# Altium schematic sheet USB.SchDoc
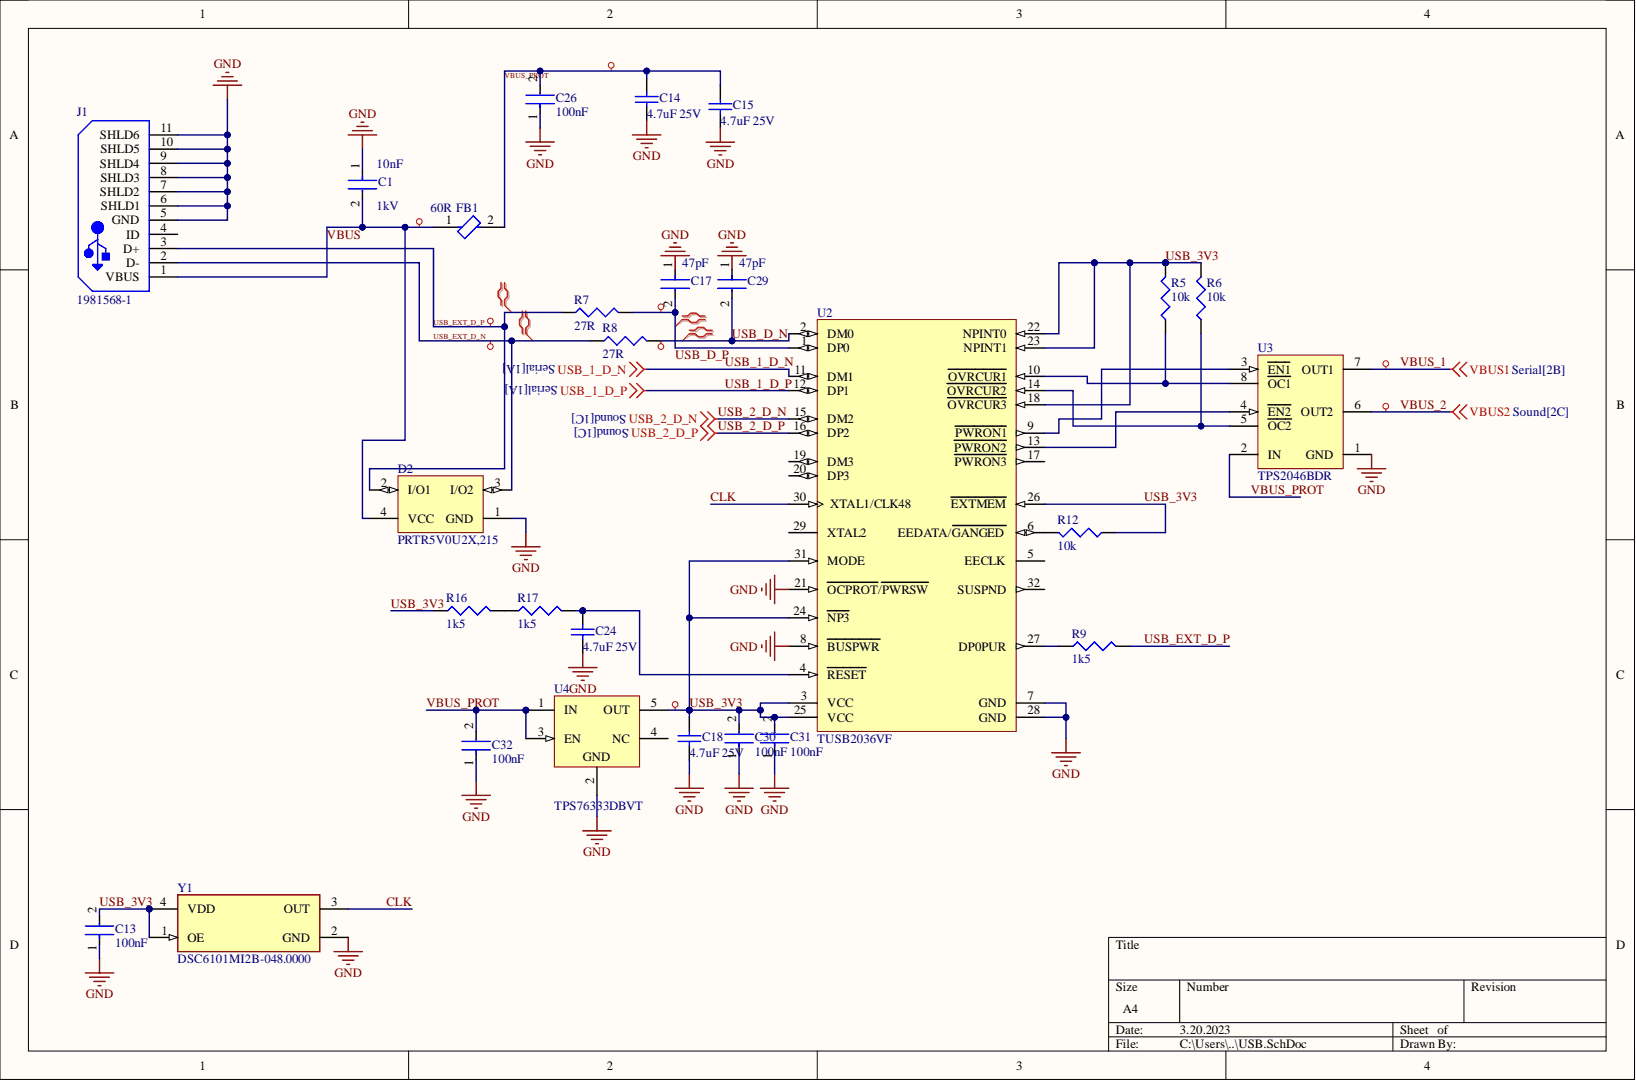
[diagram p0: USB.SchDoc]

## Parts
C1  Capacitance: 10nF  Voltage Rating: 1kV  [FP-C4532-200-0_4-IPC_B]  (hidden comment: C4532X7R3A103K200KA)
C13  Capacitance: 100nF  [FP-CL10-IPC_B]  (hidden comment: CL10B104KC8NNNC)
C14  4.7uF 25V  value 4.7uF  [CAPC1210(3225)220_M]
C15  4.7uF 25V  value 4.7uF  [CAPC1210(3225)220_M]
C17  Capacitance: 47pF  [FP-CL10-IPC_C]  (hidden comment: CL10C470JC8NNNC)
C18  4.7uF 25V  value 4.7uF  [CAPC1210(3225)220_M]
C24  4.7uF 25V  value 4.7uF  [CAPC1210(3225)220_M]
C26  Capacitance: 100nF  [FP-CL10-IPC_B]  (hidden comment: CL10B104KC8NNNC)
C29  Capacitance: 47pF  [FP-CL10-IPC_C]  (hidden comment: CL10C470JC8NNNC)
C30  Capacitance: 100nF  [FP-CL10-IPC_B]  (hidden comment: CL10B104KC8NNNC)
C31  Capacitance: 100nF  [FP-CL10-IPC_B]  (hidden comment: CL10B104KC8NNNC)
C32  Capacitance: 100nF  [FP-CL10-IPC_B]  (hidden comment: CL10B104KC8NNNC)
D2  PRTR5V0U2X,215  [NXP-SOT143B-4_V]
FB1  Impedance: 60R  [FP-BLM41-0_2-IPC_B]  (hidden comment: BLM41PG600SN1L)
J1  1981568-1  [TECO-1981568-5_V]
R5  10k  [J1-0603]
R6  10k  [J1-0603]
R7  27R  [J1-0603]
R8  27R  [J1-0603]
R9  1k5  [J1-0603]
R12  10k  [J1-0603]
R16  1k5  [J1-0603]
R17  1k5  [J1-0603]
U2  TUSB2036VF  [VF0032A_N]
U3  TPS2046BDR  [D0008A_L]
U4  TPS76333DBVT  [DBV0005A_N]
Y1  DSC6101MI2B-048.0000  [FP-C04-C04-1200-0]

## Nets
GND: C1.1 C13.1 C14.1 C15.1 C17.1 C18.1 C24.1 C26.1 C29.1 C30.1 C31.1 C32.1 D2.1(GND) J1.5(GND) J1.6(SHLD1) J1.7(SHLD2) J1.8(SHLD3) J1.9(SHLD4) J1.10(SHLD5) J1.11(SHLD6) U2.7(GND) U2.8(BUSPWR) U2.21(OCPROT/PWRSW) U2.28(GND) U3.1(GND) U4.2 Y1.2(GND)
CLK: U2.30(XTAL1/CLK48) Y1.3(OUT)
USB_1_D_N: U2.11(DM1)
USB_1_D_P: U2.12(DP1)
USB_2_D_N: U2.15(DM2)
USB_2_D_P: U2.16(DP2)
USB_3V3: C13.2 C18.2 C30.2 C31.2 R5.2 R6.2 R12.1 R16.1 U2.3(VCC) U2.18(OVRCUR3) U2.22(NPINT0) U2.23(NPINT1) U2.24(NP3) U2.25(VCC) U2.26(EXTMEM) U2.31(MODE) U4.5(OUT) Y1.1(OE) Y1.4(VDD)
USB_D_N: C29.2 R8.2 U2.2(DM0)
USB_D_P: C17.2 R7.2 U2.1(DP0)
USB_EXT_D_N: D2.3(I/O2) J1.2(D-) R8.1
USB_EXT_D_P: D2.2(I/O1) J1.3(D+) R7.1 R9.2
VBUS: C1.2 D2.4(VCC) FB1.1 J1.1(VBUS)
VBUS_1: U3.7(OUT1)
VBUS_2: U3.6(OUT2)
VBUS_PROT: C14.2 C15.2 C26.2 C32.2 FB1.2 U3.2(IN) U4.1(IN) U4.3(EN)
NetC24_2: C24.2 R17.2 U2.4(RESET)
NetR5_1: R5.1 U2.10(OVRCUR1) U3.8(OC1)
NetR6_1: R6.1 U2.14(OVRCUR2) U3.5(OC2)
NetR9_1: R9.1 U2.27(DP0PUR)
NetR12_2: R12.2 U2.6(EEDATA/GANGED)
NetR16_2: R16.2 R17.1
NetU2_9: U2.9(PWRON1) U3.3(EN1)
NetU2_13: U2.13(PWRON2) U3.4(EN2)

Not connected: J1 4(ID); U2 5(EECLK) 17(PWRON3) 19(DM3) 20(DP3) 29(XTAL2) 32(SUSPND); U4 4(NC)

## Library data of the parts
C1 = CMP-08246-006993-1: Multilayer Ceramic Capacitors 10nF ±10% 1000V X7R SMD 1812; Case Code (Imperial): 1812; Case Code (Metric): 4532; Case/Package: 1812; Component Kind: Standard; Depth: 3.2mm; Dielectric: X7R; JLCPCBPartNum: C577346; Lead Free: Lead Free; Length: 4.5mm; Library Name: Altium Content Vault; Max Operating Temperature: 125°C; Min Operating Temperature: -55°C; Mount: Surface Mount; Number of Pins: 2; Package Quantity: 1; Packaging: Tape and Reel; REACH SVHC: No SVHC; Resistor Type: Ceramic; RoHS Compliant: Yes; Series: C; Termination: SMD/SMT; Thickness: 0.087inch; Tolerance: 10%; Voltage Rating (DC): 1kV; Weight: 0.000952oz; Width: 0.126inch
C13 C26 C30-C32 = CMP-13271-004036-1: Cap Ceramic 100nF 100V X7R ±10% Pad SMD 0603 +125°C T/R; Case Code (Imperial): 0603; Case Code (Metric): 1608; Case/Package: 0603; Component Kind: Standard; JLCPCBPartNum: C15725; Length: 0.063inch; Library Name: Altium Content Vault; Max Operating Temperature: 125°C; Min Operating Temperature: -55°C; Mount: Surface Mount; Packaging: Cut Tape; Thickness: 0.035inch; Tolerance: 10%; Voltage Rating: 100V; Width: 0.031inch
C14 C15 C18 C24 = CMP-1038-02151-2: CAP 4.7uF 25V ±10% 1210 (3225 Metric) Thickness 2.2mm SMD; Case-EIA: 1210; Case-Metric: 3225; Tolerance: Â±10%; Component Kind: Standard; JLCPCBPartNum: C86052; Library Name: Altium Content Vault; Max Thickness: 2.2 mm; Rated Voltage: 25 V; Technology: SMT
C17 C29 = CMP-13271-001416-1: Cap Ceramic 47pF 100V C0G ±5% Pad SMD 0603 +125°C T/R; Case Code (Imperial): 0603; Case Code (Metric): 1608; Case/Package: 0603; Component Kind: Standard; Depth: 0.8mm; Dielectric: C0G; Height: 0.8mm; JLCPCBPartNum: C1671; Length: 1.6mm; Library Name: Altium Content Vault; Material: Ceramic; Max Operating Temperature: 125°C; Min Operating Temperature: -55°C; Mount: Surface Mount; Packaging: Tape and Reel; Radiation Hardening: No; Thickness: 0.035inch; Tolerance: 5%; Voltage Rating: 100V; Voltage Rating (DC): 100V; Width: 0.031inch
D2 = CMP-2000-05285-1: Ultra Low Capacitance Double Rail-to-Rail ESD Protection Diode, 5.5 V, 1 pF, -40 to 85 degC, 4-Pin SOT143B, RoHS, Tape and Reel; Manufacturer: NXP Semiconductors; Capacitance Value: 16pF; Configuration: Quad; Direction Type: Uni-Directional; ESD Protection Voltage: 8KV@ContactDisc; Leakage Current: 0.1uA; Number of Elements: 1; Product Depth (mm): 1.4mm; Product Height (mm): 1mm; Product Length (mm): 3mm; Rad Hardened: No; Reverse Breakdown Voltage: 6V; Reverse Stand-off Voltage: 5.5V; Suppressor Type: DiodeArrays; Component Kind: Standard; Datasheet URL: https://datasheet.ciiva.com/4479/prtr5v0u2x-102853-4479461.pdf; Datasheet Version: Rev. 02, 01/2008; JLCPCBPartNum: C12333; Leakage Current (Max): 0.1uA; Library Name: Altium Content Vault; Manufacturer URL: http://www.nxp.com/; Mounting: SurfaceMount; Mounting Style: SurfaceMount; Operating Temp Range: -40Cto85C; Operating Temperature Classification: Industrial; Operating Temperature Max Deg. C: 85C; Operating Temperature Min Deg. C: -40C; Package / Case: SOT; Package Type: SOT; Packaging: TapeandReel; Pin Count: 3+Tab; Polarity: Uni-Directional; Working Voltage: 5.5V; Working Voltage (Max): 5.5V
FB1 = CMP-0686-00754-3: Ferrite Bead, 1806, 60Ω @ 100MHz, 0.009Ω, 6A; Manufacturer Series: BLM41P; DC Resistance (DCR): 9mR; Number of Circuits: 1; Shielding: Unshielded; Tolerance: 25%; Case Code (Imperial): 1806; Case Code (Metric): 4516; Case/Package: 1806; Component Kind: Standard; Contact Plating: Tin; Core Material: Ferrite; Current: 6A; Current Rating: 6A; DC Current: 6A; DC Resistance-Max: 9mR; Depth: 1.6mm; Frequency: 100MHz; Height: 1.6mm; Inductor Type: FERRITE BEAD; JLCPCBPartNum: C85844; Lead Free: Lead Free; Length: 4.5mm; Library Name: Altium Content Vault; Material: Ferrite; Max Current Rating: 6A; Max DC Current: 6A; Max Operating Temperature: 125°C; Military Standard: Not; Min Operating Temperature: -55°C; Number of Functions: 1; Number of Lines: 1; Number of Pins: 2; Package Quantity: 1; Packaging: Tape and Reel; Radiation Hardening: No; REACH SVHC: No SVHC; RoHS Compliant: Yes; Series: BLM41P; Terminal Finish: Tin; Termination: SMD/SMT; Test Frequency: 100MHz; Width: 1.6mm
J1 = CMP-2000-05408-1: Micro USB Receptacle Standard Type B Assy, 5-Pin SMD, RoHS, Tape and Reel; Manufacturer: TE Connectivity; Body Orientation: RightAngle; Current Rating (Max): 1/ContactA; Gender: RCP; Number of Contacts: 5POS; Number of Ports: 1Port; Pitch (mm): 0.65mm; Product Depth (mm): 5.6mm; Product Height (mm): 3mm; Product Length (mm): 8mm; Voltage Rating Max: 30VAC; Component Kind: Standard; Contact Material: CopperAlloy; Contact Plating: GoldOverNickel; Datasheet URL: http://www.mouser.com/ds/2/418/NG_CD_1981568_E2-621296.pdf; Datasheet Version: Rev. E2, 08/2015; Housing Material: Thermoplastic; JLCPCBPartNum: C428487; Library Name: Altium Content Vault; Manufacturer URL: http://www.te.com/; Mounting Style: SurfaceMount; Number of Terminals: 5; Operating Temp Range: -55Cto85C; Operating Temperature Max Deg. C: 85C; Operating Temperature Min Deg. C: -55C; Packaging: TapeandReel; Termination Method: Solder; Type: MicroUSB
R5-R9 R12 R16 R17 = Res3: Resistor; Component Kind: Standard; LatestRevisionDate: 29-May-2009; LatestRevisionNote: IPC-7351 Footprint Added.; Library Name: Miscellaneous Devices.IntLib; PackageDescription: Chip Resistor; PackageReference: J1-0603; Published: 8-Jun-2000; Signal Integrity: Res; Simulation: RESISTOR; Publisher: Altium Limited
  R5 R6 R12: JLCPCBPartNum: C25804; Value: 10k
  R7 R8: JLCPCBPartNum: C192531; Value: 27R
  R9 R16 R17: JLCPCBPartNum: C192164; Value: 1k5
U2 = CMP-0309-00077-2: 2 / 3-Port Hub for USB with Optional Serial EEPROM Interface, 3.3V, 0 to 70 degC, 32-Pin LQFP (VF), Green (RoHS & no Sb/Br); Manufacturer: Texas Instruments; SubFamily: USB Hub Controller; Supply Voltage(s)(V): 3.3; USB Full Speed: True; USB Speed: Full; Component Kind: Standard; ComponentLink1Description: Datasheet; ComponentLink1URL: http://www.ti.com/general/docs/lit/getliterature.tsp?genericPartNumber=TUSB2036&fileType=pdf; ComponentLink2Description: Package Specification; ComponentLink2URL: http://www.ti.com/litv/pdf/mtqf002b; DatasheetVersion: SLLS372E; Eco-Plan: Green (RoHS & no Sb/Br); Integrated MCU Architecture: N/A; JLCPCBPartNum: C43665; Library Name: Altium Content Vault; Mounting Technology: Surface Mount; PackageDescription: 32-Pin Plastic Quad Flatpack, 7 x 7 mm Body, 0.8 mm Pitch; PackageReference: VF0032A; PackageVersion: revD, Apr-2000; PartNumber: TUSB2036VF
U3 = CMP-0337-00031-2: Current-Limited Power-Distribution Switch, 0.5 A Current Limit, -40 to 85 degC, 8-pin SOIC (D), Green (RoHS & no Sb/Br); Manufacturer: Texas Instruments; Continuous Current(Max)(A): 0.25; Current Limit(A): 0.5; Enable: Active Low; NEMKO: TRUE; Number of Switches: 2; Rating: Catalog; rDS(on) per FET(Typ)(mOhms): 70; Vin(Max)(V): 5.5; Vin(Min)(V): 2.7; Code_JEDEC: MS-012AA; Component Kind: Standard; ComponentLink1Description: Datasheet; ComponentLink1URL: http://www.ti.com/general/docs/lit/getliterature.tsp?genericPartNumber=TPS2046B&fileType=pdf; DatasheetVersion: SLVS532C; Eco-Plan: Green (RoHS & no Sb/Br); Library Name: Altium Content Vault; Mounting Technology: Surface Mount; PackageDescription: 8-Pin Plastic Small Outline Integrated Circuit, 4.9 x 3.9 mm, Pitch 1.27 mm Pitch; PackageReference: D0008A; PackageVersion: revM, Jun-2011; PartNumber: TPS2046BDR; UL Listed: TRUE
U4 = CMP-0394-00563-2: Single Output LDO, 150 mA, Fixed 3.3 V Output, 2.7 to 10 V Input, with Low IQ, 5-pin SOT-23 (DBV), -40 to 125 degC, Green (RoHS & no Sb/Br); Manufacturer: Texas Instruments; Accuracy(%): 3; Fixed Output Options(V): 3.3; Iout(Max)(A): 0.15; Iq(Typ)(mA): 0.08; SubFamily: Single Channel LDO; Vdo(Typ)(mV): 300; Vin(Max)(V): 10; Vin(Min)(V): 2.7; Code_JEDEC: MO-178; Component Kind: Standard; ComponentLink1Description: Manufacturer URL; ComponentLink1URL: http://www.ti.com/; ComponentLink2Description: Datasheet; ComponentLink2URL: http://www.ti.com/general/docs/lit/getliterature.tsp?genericPartNumber=TPS76333&fileType=pdf; ComponentLink3Description: Package Specification; ComponentLink3URL: http://www.ti.com/litv/pdf/mpds018i; DatasheetVersion: SLVS181H; Eco-Plan: Green (RoHS & no Sb/Br); JLCPCBPartNum: C7100; Library Name: Altium Content Vault; Mounting Technology: Surface Mount; PackageDescription: 5-Pin Plastic Small Outline, Body 1.60 Ãƒâ€” 2.90 mm, Pitch 0.95 mm; PackageReference: DBV0005A; PackageVersion: 4073253-4/K; PartNumber: TPS76333DBVT; Vout Adj(Max)(V): 3.3; Vout Adj(Min)(V): 3.3
Y1 = CMP-06255-000002-1: MEMS OSC XO 48.0000MHZ CMOS SMD; Manufacturer: Microchip; Manufacturer Part Number: DSC6101MI2A-024.0000T; Frequency: 24MHz; Max Junction Temp: +150°C; Max Supply Voltage: 3.63V; Min Supply Voltage: 1.71V; Stability: 25ppm; Standoff Height: 0mm; Ambient Temperature Range High: +85°C; Ambient Temperature Range Low: -40°C; Automotive: No; Category: Crys; Component Kind: Standard; ComponentLink2Description: Datasheet; ComponentLink2URL: http://ww1.microchip.com/downloads/en/DeviceDoc/20005624B.pdf; Device Class L1: Passive Components; Device Class L2: Crystals / Oscillators; Device Class L3: Oscillators; Digikey Description: MEMS OSC XO 24.0000MHZ CMOS SMD; Footprint Url: http://ww1.microchip.com/downloads/en/DeviceDoc/04L_VFLGA_2-0x1-6x0-89_ASA_C04-01200b.pdf; Height: 0.89mm; JLCPCBPartNum: C1671481; Lead Free: Yes; Library Name: Altium Content Vault; Mouser Description: Ultra-Low Power, Mems Oscillator, 2016, -40 To 85, 25Ppm4 Vflga 2.0X1.6X0.89Mm T/R; Octopart: https://octopart.com/dsc6101mi2a-024.0000t-microchip-75516980; Package: C04-C04-1200; RoHS: TRUE; Series: DSC61; Temperature: to +150°C; Temperature Range High: +150°C
